# Altium schematic sheet PMODs.SchDoc(PMODs)
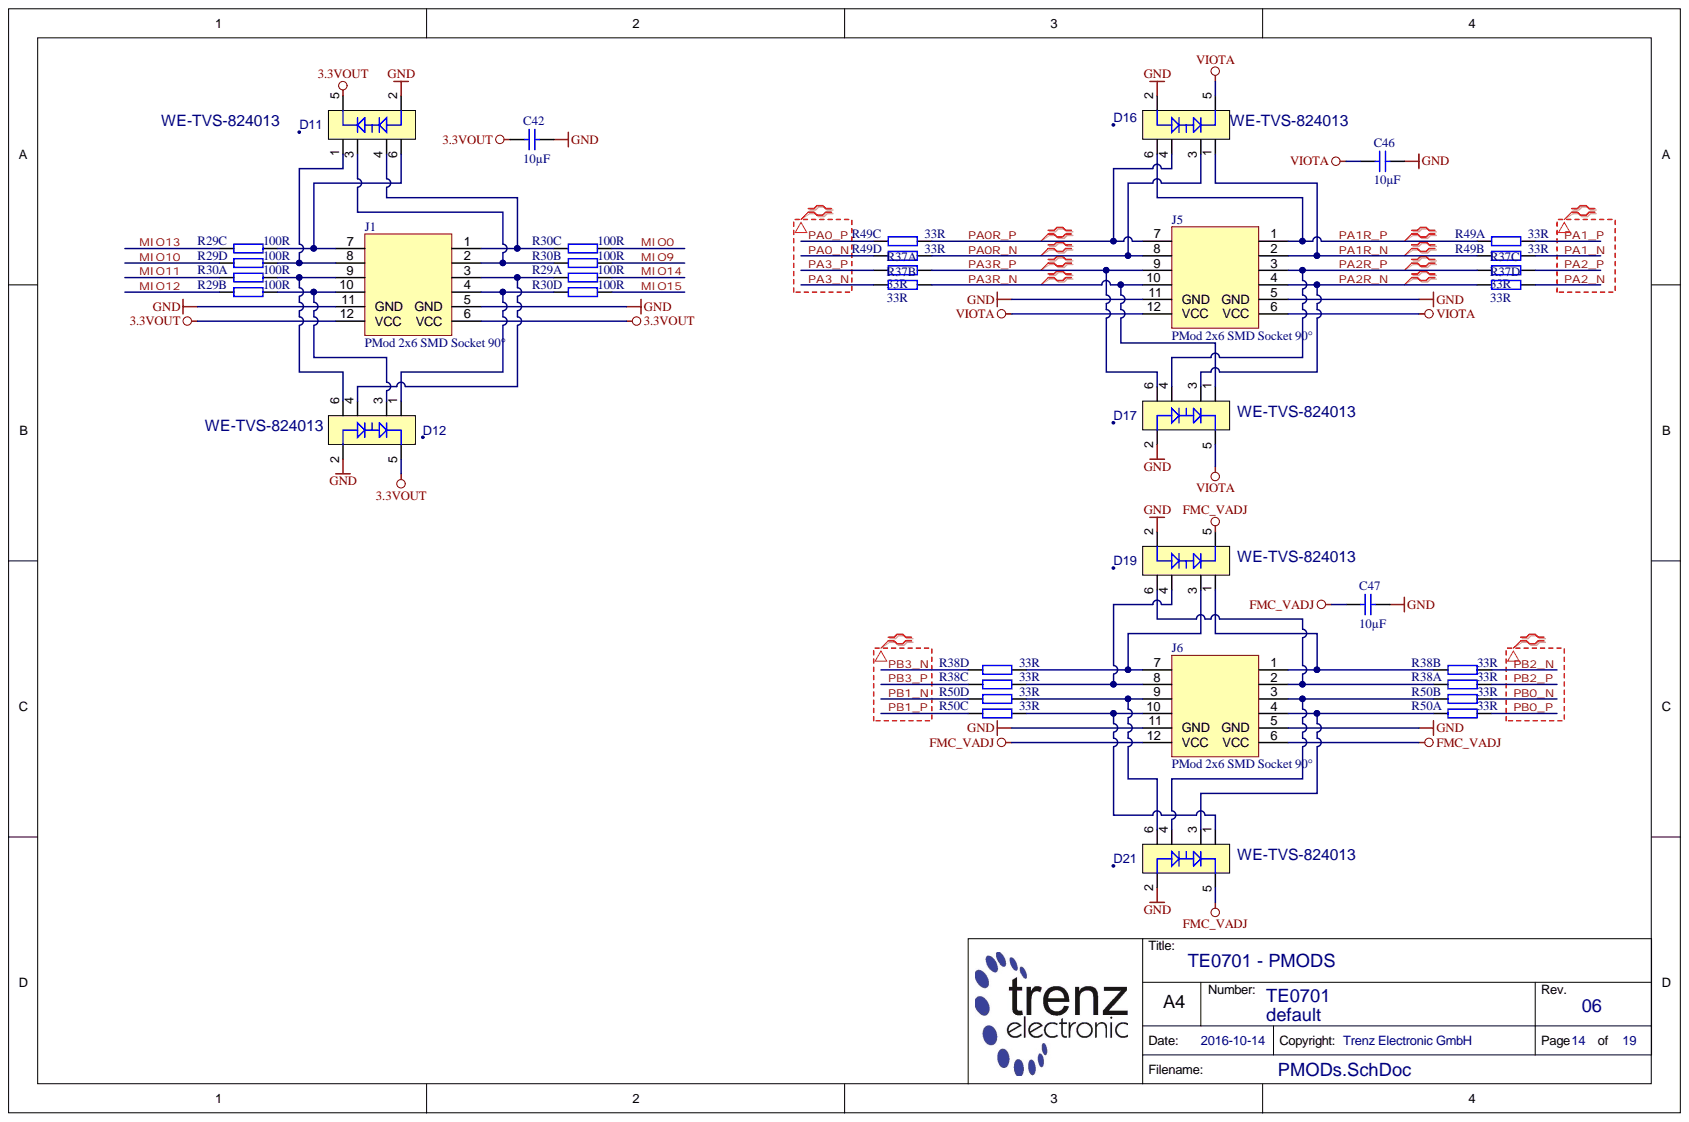
[diagram p0: PMODs.SchDoc]

## Parts
C42  10µF  [CAPC2012X135N]
C46  10µF  [CAPC2012X135N]
C47  10µF  [CAPC2012X135N]
D11  WE-TVS-824013  [SOT95P280X145-6N]
D12  WE-TVS-824013  [SOT95P280X145-6N]
D16  WE-TVS-824013  [SOT95P280X145-6N]
D17  WE-TVS-824013  [SOT95P280X145-6N]
D19  WE-TVS-824013  [SOT95P280X145-6N]
D21  WE-TVS-824013  [SOT95P280X145-6N]
J1  PMod 2x6 SMD Socket 90°  [610112249221]
J5  PMod 2x6 SMD Socket 90°  [610112249221]
J6  PMod 2x6 SMD Socket 90°  [610112249221]
R29  100R  [RESCAV80P160X320X70-8N]  (parts A,B,C,D)
R30  100R  [RESCAV80P160X320X70-8N]  (parts A,B,C,D)
R37  33R  [RESCAX50P100X200X55-8N]  (parts A,B,C,D)
R38  33R  [RESCAX50P100X200X55-8N]  (parts A,B,C,D)
R49  33R  [RESCAX50P100X200X55-8N]  (parts A,B,C,D)
R50  33R  [RESCAX50P100X200X55-8N]  (parts A,B,C,D)

## Nets
3.3VOUT: C42.1 D11.5 D12.5 J1.6(VCC) J1.12(VCC)
FMC_VADJ: C47.1 D19.5 D21.5 J6.6(VCC) J6.12(VCC)
GND: C42.2 C46.2 C47.2 D11.2 D12.2 D16.2 D17.2 D19.2 D21.2 J1.5(GND) J1.11(GND) J5.5(GND) J5.11(GND) J6.5(GND) J6.11(GND)
VIOTA: C46.1 D16.5 D17.5 J5.6(VCC) J5.12(VCC)
MIO0: R30.3
MIO9: R30.2
MIO10: R29.4
MIO11: R30.1
MIO12: R29.2
MIO13: R29.3
MIO14: R29.1
MIO15: R30.4
PA0_N: R49.5
PA0_P: R49.6
PA0R_N: D16.3 J5.8 R49.4(R37A)
PA0R_P: D16.4 J5.7 R49.3
PA1_N: R49.7(R37C)
PA1_P: R49.8
PA1R_N: D16.1 J5.2 R49.2
PA1R_P: D16.6 J5.1 R49.1
PA2_N: R37.5
PA2_P: R37.6(R37D)
PA2R_N: D17.3 J5.4 R37.4
PA2R_P: D17.4 J5.3 R37.3
PA3_N: R37.7
PA3_P: R37.8
PA3R_N: D17.1 J5.10 R37.2
PA3R_P: D17.6 J5.9 R37.1(R37B)
PB0_N: R50.2
PB0_P: R50.1
PB1_N: R50.4
PB1_P: R50.3
PB2_N: R38.7
PB2_P: R38.8
PB3_N: R38.5
PB3_P: R38.6
NetD11_1: D11.1 J1.8 R29.5
NetD11_3: D11.3 J1.2 R30.7
NetD11_4: D11.4 J1.1 R30.6
NetD11_6: D11.6 J1.7 R29.6
NetD12_1: D12.1 J1.4 R30.5
NetD12_3: D12.3 J1.10 R29.7
NetD12_4: D12.4 J1.3 R29.8
NetD12_6: D12.6 J1.9 R30.8
NetD19_1: D19.1 J6.1 R38.2
NetD19_3: D19.3 J6.7 R38.4
NetD19_4: D19.4 J6.8 R38.3
NetD19_6: D19.6 J6.2 R38.1
NetD21_1: D21.1 J6.10 R50.6
NetD21_3: D21.3 J6.4 R50.8
NetD21_4: D21.4 J6.3 R50.7
NetD21_6: D21.6 J6.9 R50.5
N00400:
N00401:
N00402:
N00403:

## Library data of the parts
C42 C46 C47 = 24380: KON 10µF 16V X7R SMD 10%; Database Table Name: C_2012_0805; Mixed: SMT; Preferred: Yes; Value: 10u; AN: 24380; Case: 2012 (0805); Component Kind: Standard; Library Name: TE.DbLib; Technology: X7R; Tolerance: 10%; Voltage: 16V
D11 D12 D16 D17 D19 D21 = 23986: TVS Diode Array WE-TVS 824013; H-ART: 824013; Mixed: SMT; Preferred: Yes; AN: 23986; Case: SOT23-6; Component Kind: Standard; Database Table Name: DIODE; Library Name: TE.DbLib; Trenz-Stock: Yes; HelpURL: B:\AD\adlib\datasheet\WE-TVS-824013
J1 J5 J6 = 27149: Database Table Name: CON_IO; Mixed: SMT; Trenz-Stock: Yes; AN: 27149; Component Kind: Standard; Description: PMod 2x6 SMD Socket 90°; H-ART: 610112249221; Library Name: TE.DbLib; HelpURL: B:\ad\adlib\datasheet\610112249221
R29 R30 = 22868: WID SMD Netz 4 fach 100R; Database Table Name: R_NET; Mixed: SMT; Preferred: Yes; Tolerance: 5%; Value: 100; AN: 22868; Case: 3216 (1206); Component Kind: Standard; Library Name: TE.DbLib
R37 R38 R49 R50 = 23422: WID SMD Netz 4 fach 33R 5%; Database Table Name: R_NET; H-ART: YC124-JR-0733RL; Mixed: SMT; Preferred: Yes; Value: 33; AN: 23422; Case: 0402x4 0804; Component Kind: Standard; Library Name: TE.DbLib; Technology: TK200; Tolerance: 5%; HelpURL: B:\AD\adlib\datasheet\MNR04
